# Altium schematic sheet SH3 - SENSORS.SchDoc
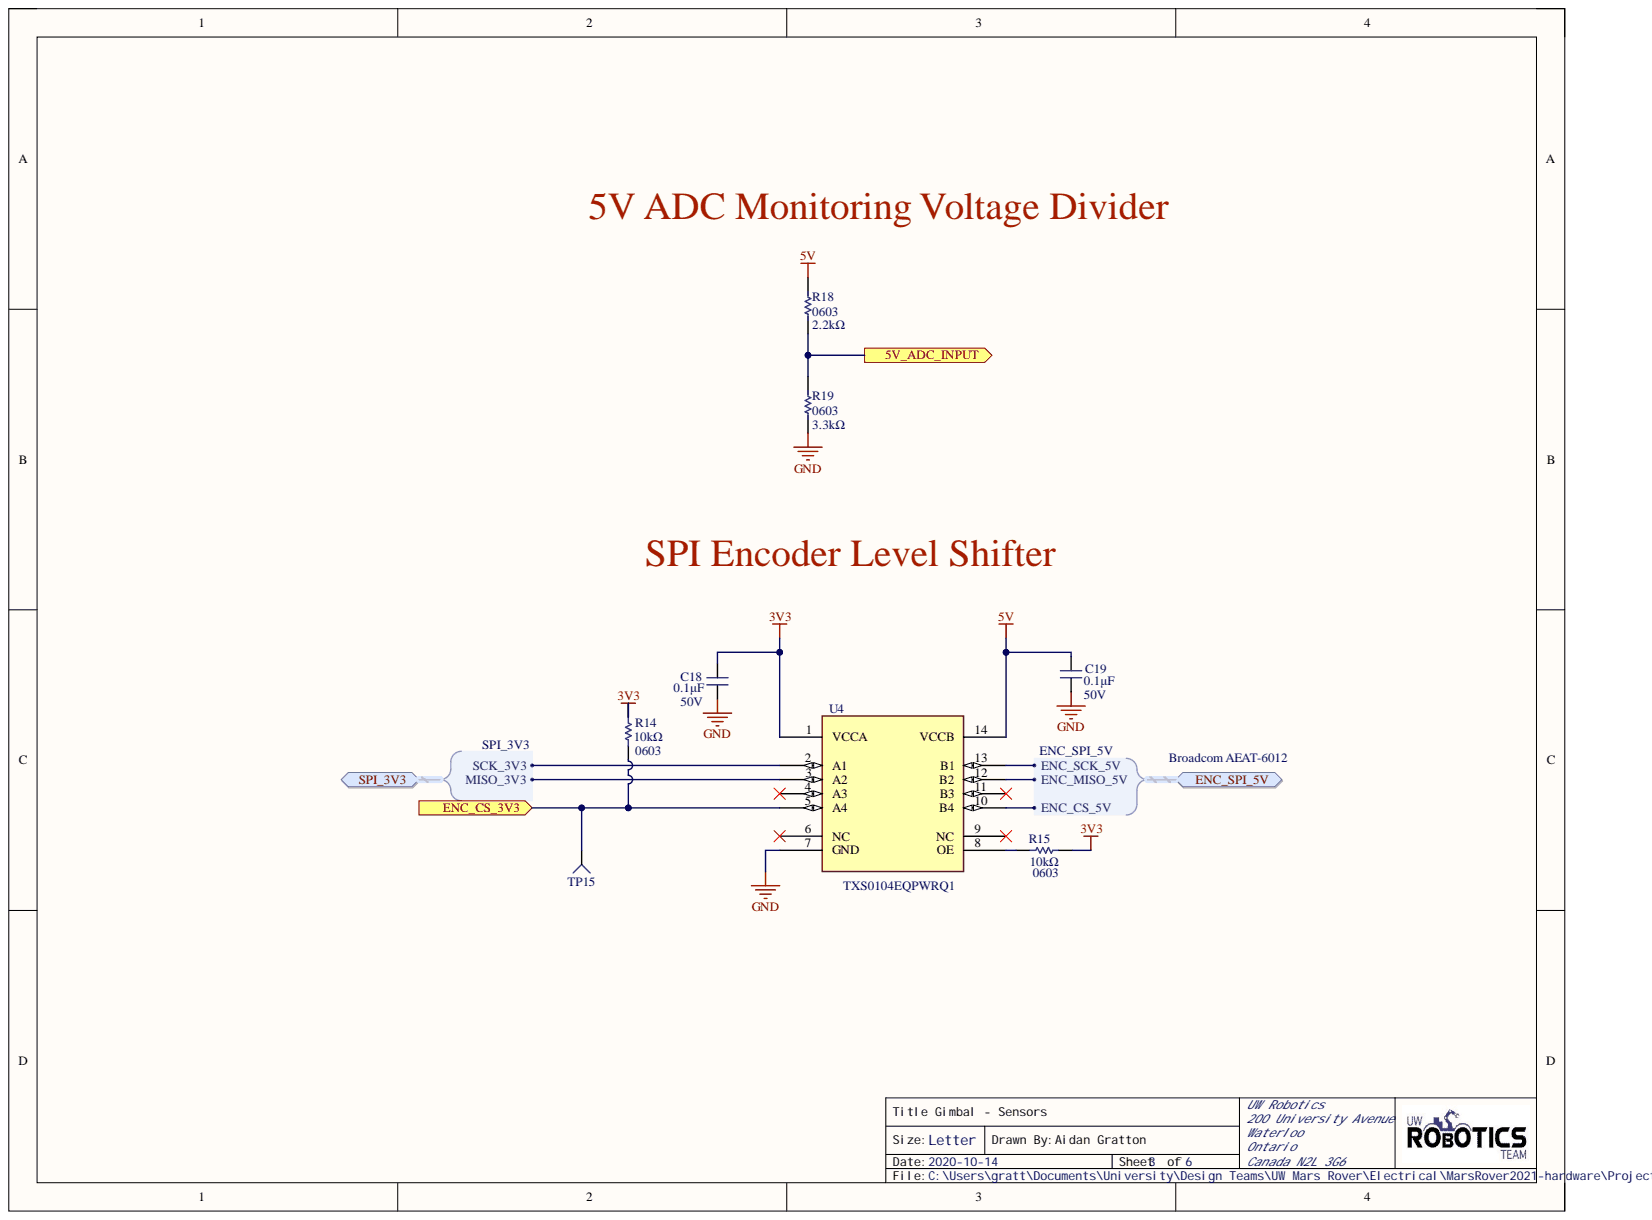
[diagram p0: SH3 - SENSORS.SchDoc]

## Parts
C18  value 0.1μF  Voltage Rating: 50V  [CAP0603]  (hidden comment: CAP_0.1uF_50V_0603)
C19  value 0.1μF  Voltage Rating: 50V  [CAP0603]  (hidden comment: CAP_0.1uF_50V_0603)
R14  value 10kΩ  Case Code (Imperial): 0603  [RESC0603(1608)_N]  (hidden comment: RES_10kΩ_0603)
R15  value 10kΩ  Case Code (Imperial): 0603  [RESC0603(1608)_N]  (hidden comment: RES_10kΩ_0603)
R18  value 2.2kΩ  Case Code (Imperial): 0603  [RESC0603(1608)_N]  (hidden comment: RES_2.2kΩ_0603)
R19  value 3.3kΩ  Case Code (Imperial): 0603  [RESC0603(1608)_N]  (hidden comment: RES_3.3kΩ_0603)
TP15  TESTPOINT_1MM_CIRCLE  [TESTPOINT_CIRCLE_1MM]  (value not drawn)
U4  TXS0104EQPWRQ1  [TXS0104EQPWRQ1]

## Ports
5V_ADC_INPUT, ENC_CS_3V3, ENC_SPI_5V, ENC_SPI_5V.ENC_CS_5V, ENC_SPI_5V.ENC_MISO_5V, ENC_SPI_5V.ENC_SCK_5V, SPI_3V3, SPI_3V3.MISO_3V3, SPI_3V3.SCK_3V3

## Nets
3V3: C18.2 R14.2 R15.2 U4.1(VCCA)
5V: C19.2 R18.2 U4.14(VCCB)
GND: C18.1 C19.1 R19.1 U4.7(GND)
5V_ADC_INPUT: R18.1 R19.2 ; port 5V_ADC_INPUT
ENC_CS_3V3: R14.1 TP15.1 U4.5(A4) ; port ENC_CS_3V3
ENC_SPI_5V.ENC_CS_5V: U4.10(B4) ; port ENC_SPI_5V
ENC_SPI_5V.ENC_MISO_5V: U4.12(B2) ; port ENC_SPI_5V
ENC_SPI_5V.ENC_SCK_5V: U4.13(B1) ; port ENC_SPI_5V
SPI_3V3.MISO_3V3: U4.3(A2) ; port SPI_3V3
SPI_3V3.SCK_3V3: U4.2(A1) ; port SPI_3V3
NetR15_1: R15.1 U4.8(OE)

Not connected: U4 4(A3) 6(NC) 9(NC) 11(B3)

## Library data of the parts
C18 C19 = CAP_0.1uF_50V_0603: CAP CER 0.1UF 50V X7R 0603; Manufacturer 1: Yageo; Manufacturer Part Number 1: CC0603KRX7R9BB104; Case Code (Imperial): 0603; Case Code (Metric): 1608; Component Kind: Standard; Dielectric: X7R; Dimensions: 0.063" L x 0.031" W (1.60mm x 0.80mm); Mount: Surface Mount; Package: 0603 (1608 Metric); Signal Integrity: Cap; Simulation: CAP; Supplier 1: Digi-Key; Supplier Part Number 1: 311-1344-1-ND; Temperature Coefficient: X7R; Library Name: UWRT_Schematic_Library_2020.SchLib
R14 R15 = RES_10kΩ_0603: RES SMD 10K OHM 5% 1/10W 0603; Manufacturer 1: Yageo; Manufacturer Part Number 1: RC0603JR-0710KL; Case Code (Metric): 1608; Component Kind: Standard; Dimensions: 0.063" L x 0.031" W (1.60mm x 0.80mm); Mount: Surface Mount; Package: 0603 (1608 Metric); Signal Integrity: Res; Simulation: RESISTOR; Supplier 1: Digi-Key; Supplier Part Number 1: 311-10KGRCT-ND; Tolerance: 5%; Library Name: UWRT_Schematic_Library_2020.SchLib
R18 = RES_2.2kΩ_0603: RES SMD 2.2K OHM 1% 1/10W 0603; Manufacturer 1: Yageo; Manufacturer Part Number 1: RC0603FR-072K2L; Case Code (Metric): 1608; Component Kind: Standard; Dimensions: 0.063" L x 0.031" W (1.60mm x 0.80mm); Mount: Surface Mount; Package: 0603 (1608 Metric); Signal Integrity: Res; Simulation: RESISTOR; Supplier 1: Digi-Key; Supplier Part Number 1: 311-2.20KHRCT-ND; Tolerance: 1%; Library Name: UWRT_Schematic_Library_2020.SchLib
R19 = RES_3.3kΩ_0603: RES SMD 3.3K OHM 5% 1/10W 0603; Manufacturer 1: Yageo; Manufacturer Part Number 1: RC0603JR-073K3L; Case Code (Metric): 1608; Component Kind: Standard; Dimensions: 0.063" L x 0.031" W (1.60mm x 0.80mm); Mount: Surface Mount; Package: 0603 (1608 Metric); Signal Integrity: Res; Simulation: RESISTOR; Supplier 1: Digi-Key; Supplier Part Number 1: 311-3.3KGRCT-ND; Tolerance: 5%; Library Name: UWRT_Schematic_Library_2020.SchLib
TP15 = TESTPOINT_1MM_CIRCLE: TESTPOINT 1MM CIRCLE; Component Kind: Standard; Library Name: UWRT_Schematic_Library_2020.SchLib
U4 = IC_LVL_SHIFTER_4_CH: IC BIDIRECTIONAL LEVEL SHIFTER 4 CHANNEL 14TSSOP; Manufacturer: Texas Instruments; Data Rate: 24Mbps; VCCA Max: 3V6 MAX; VCCA Voltage: 1.65 V ~ 3.6 V; VCCB Max: 5V5 MAX; VCCB Voltage: 2.3 V ~ 5.5 V; Component Kind: Standard; Max Operating Temperature: 125°C; Min Operating Temperature: -40°C; Mount: Surface Mount; MPN: TXS0104EQPWRQ1; Package: 14-TSSOP; Supplier 1: Digi-Key; Supplier Part Number 1: 296-41034-1-ND; Library Name: UWRT_Schematic_Library_2020.SchLib
